# Altium schematic sheet Tof.SchDoc
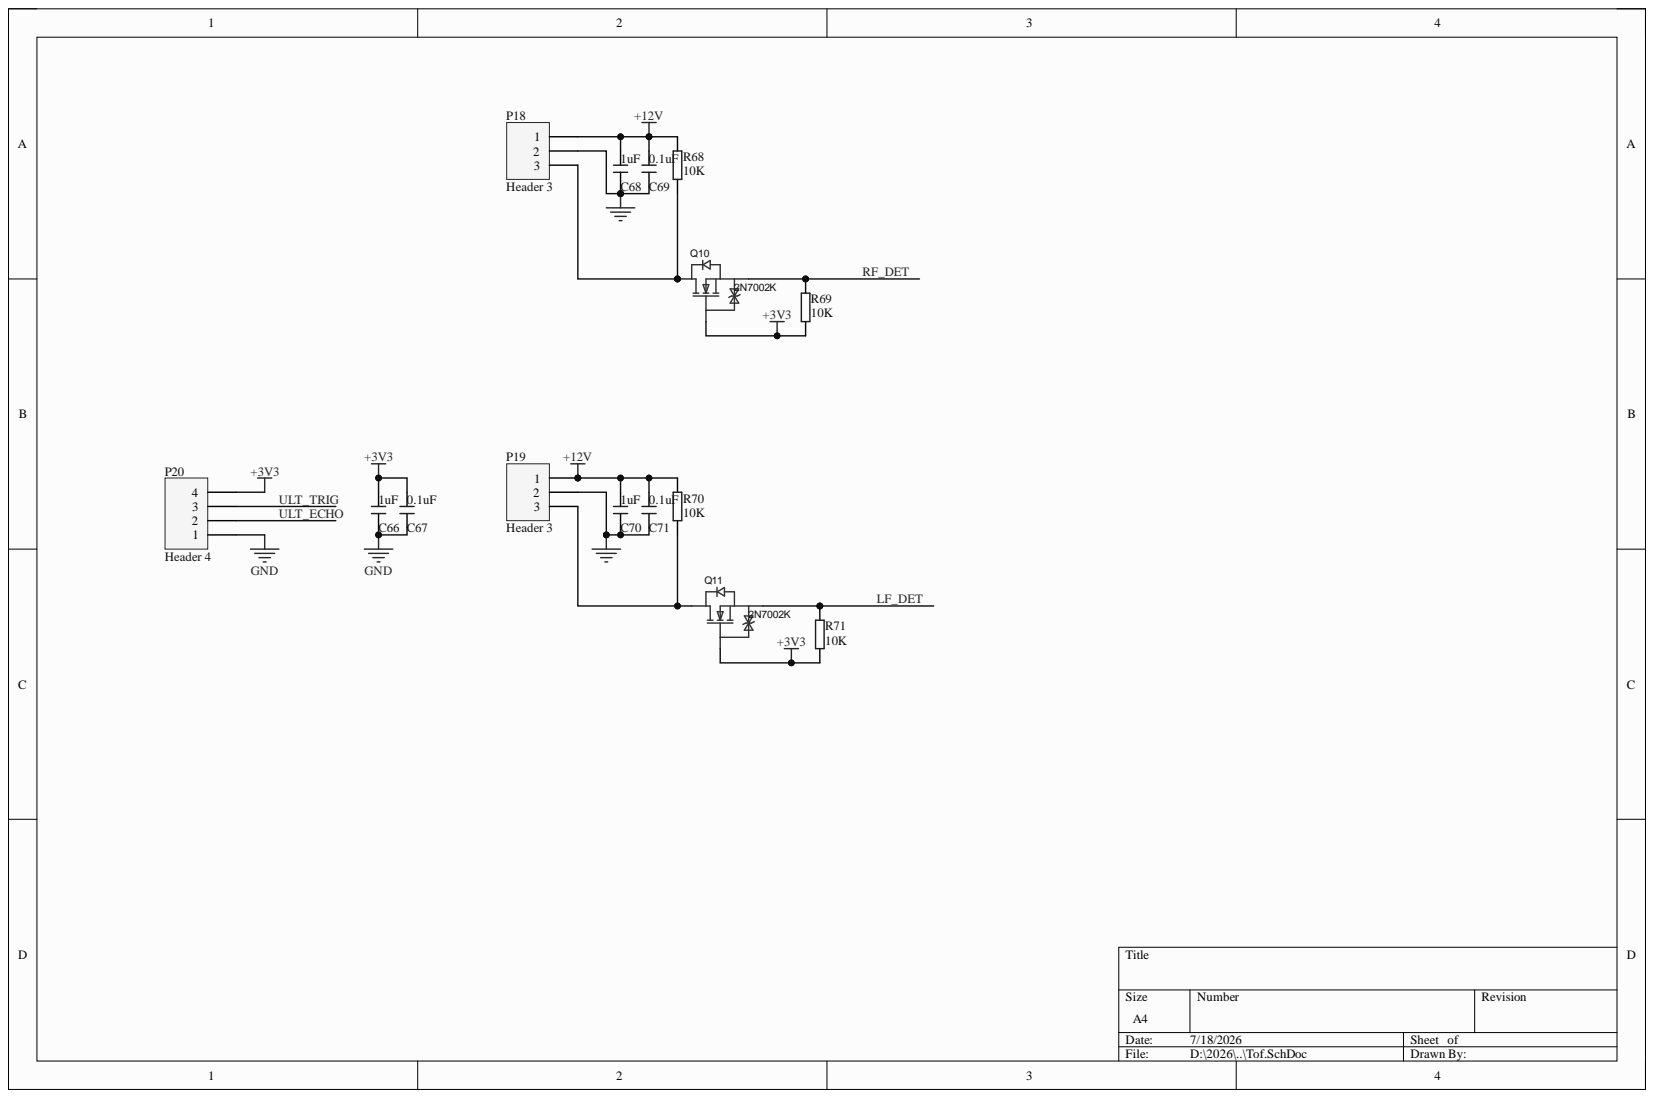
[diagram p0: Tof.SchDoc]

## Parts
C66  1uF  [C 0603_L]
C67  0.1uF  [C 0603_L]
C68  1uF  [C 0603_L]
C69  0.1uF  [C 0603_L]
C70  1uF  [C 0603_L]
C71  0.1uF  [C 0603_L]
P18  Header 3  [PH2.0-LS-3P]
P19  Header 3  [PH2.0-LS-3P]
P20  Header 4  [PH2.0-LS-4P]
Q10  2N7002K  [SOT-23_L2.9-W1.3-P1.90-LS2.4-BR]
Q11  2N7002K  [SOT-23_L2.9-W1.3-P1.90-LS2.4-BR]
R68  10K  [R 0603_L]
R69  10K  [R 0603_L]
R70  10K  [R 0603_L]
R71  10K  [R 0603_L]

## Nets
+3V3: C66.1 C67.1 P20.4 Q10.1 Q11.1 R69.2 R71.2
+12V: C68.1 C69.1 C70.1 C71.1 P18.1 P19.1 R68.2 R70.2
GND: C66.2 C67.2 C68.2 C69.2 C70.2 C71.2 P18.2 P19.2 P20.1
LF_DET: Q11.2 R71.1
RF_DET: Q10.2 R69.1
ULT_ECHO: P20.2
ULT_TRIG: P20.3
NetP18_3: P18.3 Q10.3 R68.1
NetP19_3: P19.3 Q11.3 R70.1

## Library data of the parts
C66-C71 = C: 贴片电容; Component Kind: Standard; Library Name: GS_SchLib.SchLib
P18 P19 = Header 3: Header, 3-Pin; Component Kind: Standard; LatestRevisionDate: 17-Jul-2002; LatestRevisionNote: Re-released for DXP Platform.; Library Name: Miscellaneous Connectors.IntLib; Signal Integrity: Connector; Publisher: Altium Limited
P20 = Header 4: Header, 4-Pin; Component Kind: Standard; LatestRevisionDate: 17-Jul-2002; LatestRevisionNote: Re-released for DXP Platform.; Library Name: Miscellaneous Connectors.IntLib; Signal Integrity: Connector; Publisher: Altium Limited
Q10 Q11 = 2N7002K: 数量:1个N沟道;漏源电压(Vdss):60V;连续漏极电流(Id):300mA;导通电阻(RDS(on)):2Ω@10V;耗散功率(Pd):350mW;阈值电压(Vgs(th)):2.5V@1mA;; Manufacturer: EIC; AddInBom: yes; Current - Continuous Drain(Id): 300mA; Drain to Source Voltage: 60V; Gate Charge(Qg): 800pC@4.5V; Input Capacitance(Ciss): 50pF; ModelId: c62791f0466c4ae2a892c056b845b0ca聨0819f05c4eef4c71ace90d822a990e87; ModelName: SOT-23-3P_L2.9-W1.3-H1.0-LS2.4-P0.95; ModelTransform: 98.64468,114.173,0,180,0,0,0.001,-0.002,0; Number: 1个N沟道; Output Capacitance(Coss): 25pF; Pd - Power Dissipation: 350mW; RDS(on): 2Ω@10V; Reverse Transfer Capacitance (Crss@Vds): 5pF; Symbol: 2N7002K_C2978790; Type: N沟道; Component Kind: Standard; ConvertToPcb: yes; Datasheet: https://item.szlcsc.com/datasheet/2N7002K/3393615.html; Device: 2N7002K; FootprintName: SOT-23_L2.9-W1.3-P1.90-LS2.4-BR; Gate Threshold Voltage (Vgs(th)): 2.5V@1mA; JLCPCB Part Class: Extended Part; LCSC Part Name: N沟道增强型MOSFET,电流:300mA,耐压:60V; ManufacturerPart: 2N7002K; Operating Temperature: -55℃~+150℃; Supplier: LCSC; Supplier Footprint: SOT-23; SupplierPart: C2978790
R68-R71 = RES3-SMD: SMD筿; Component Kind: Standard; Library Name: Orson SCH.SCHLIB
